# Altium schematic sheet MCU.SchDoc(MCU)
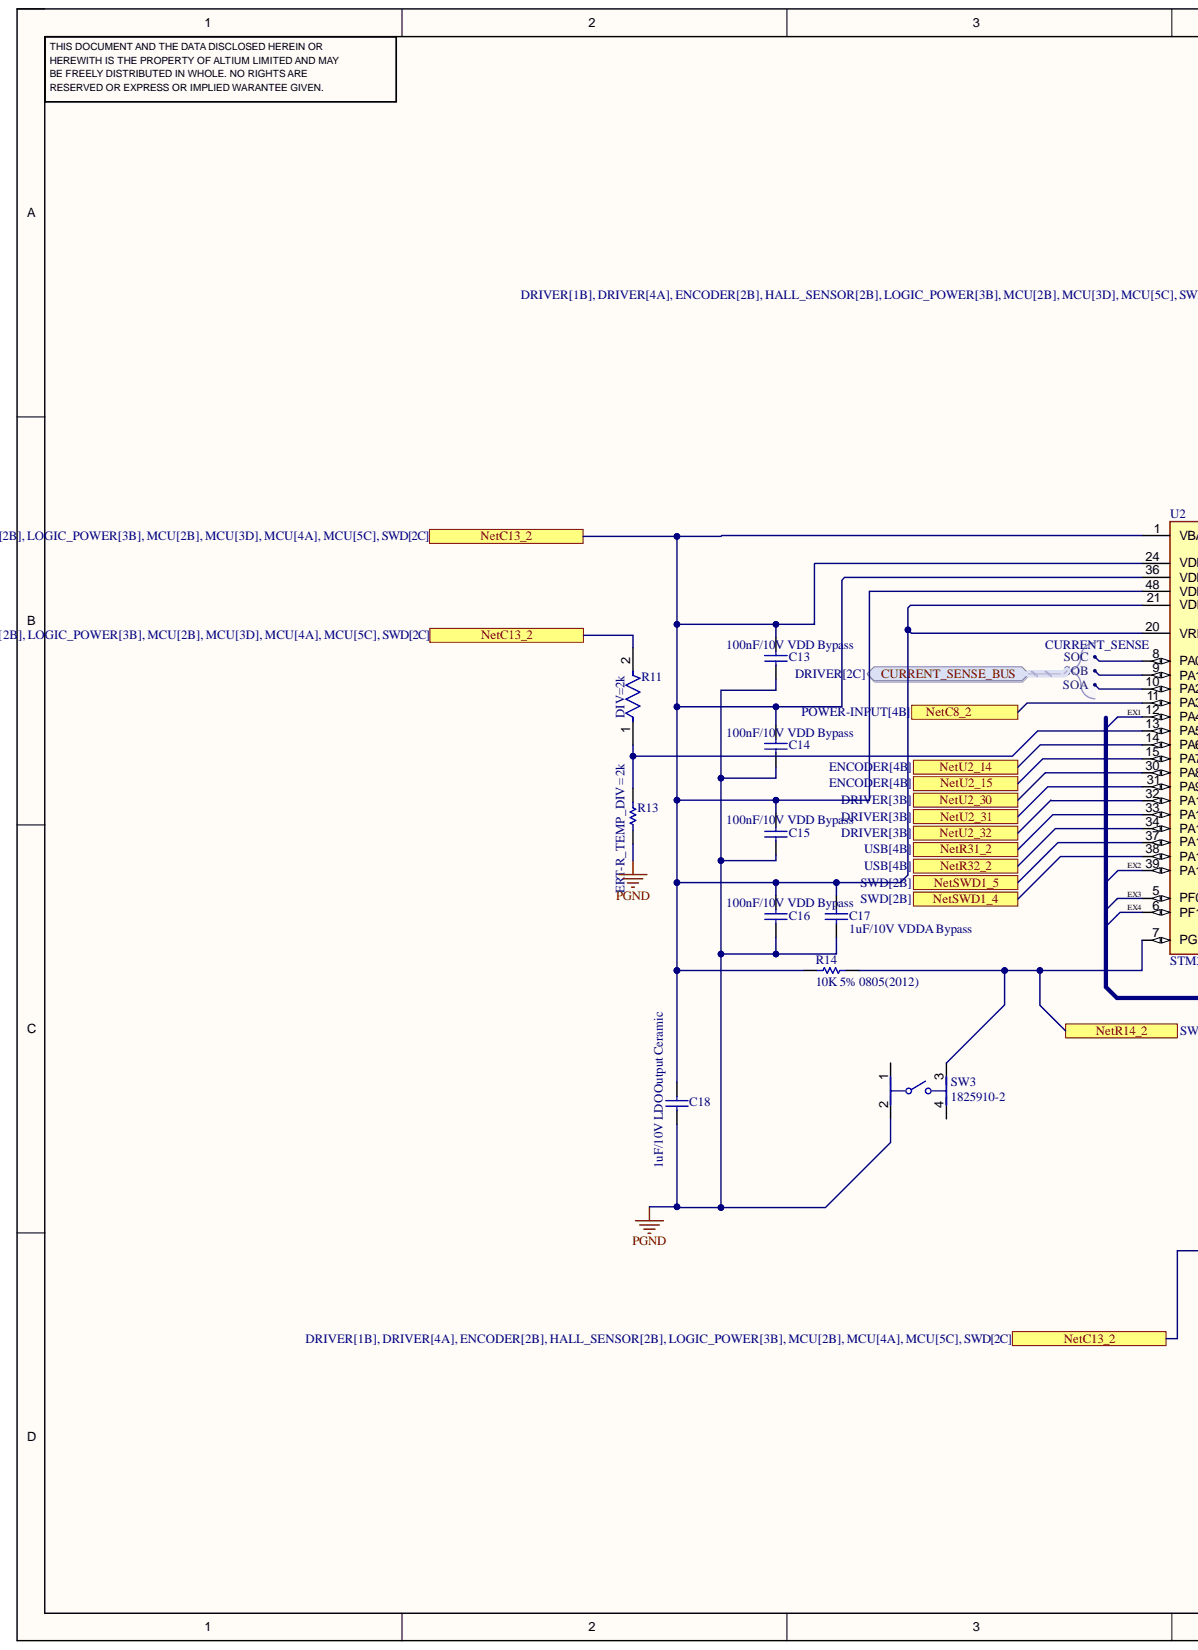
[diagram p0: MCU.SchDoc, left]
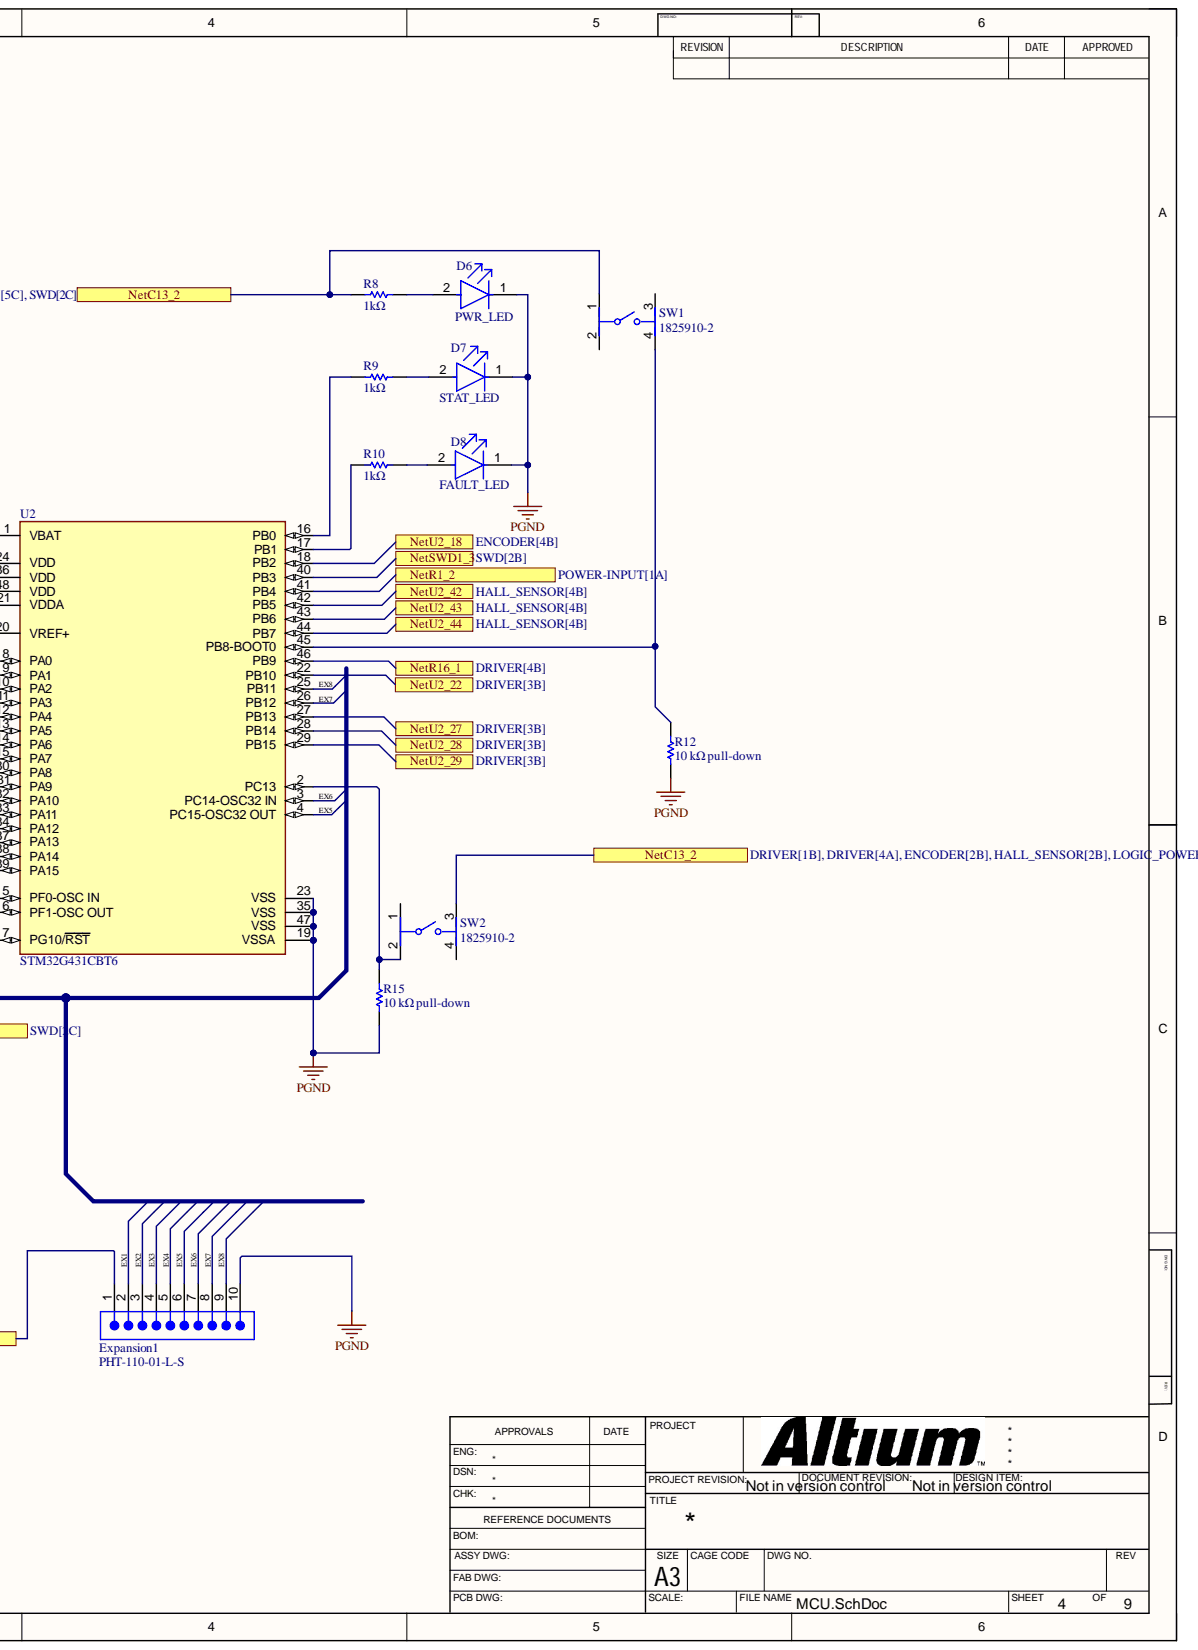
[diagram p1: MCU.SchDoc, right]

## Parts
C13  100nF/10V VDD Bypass  [CAPC0805(2012)100_N]
C14  100nF/10V VDD Bypass  [CAPC0805(2012)100_N]
C15  100nF/10V VDD Bypass  [CAPC0805(2012)100_N]
C16  100nF/10V VDD Bypass  [CAPC0805(2012)100_N]
C17  1uF/10V VDDA Bypass  [CAPC1206(3216)150_M]
C18  1uF/10V LDO Output Ceramic  [CAPC1206(3216)150_M]
D6  PWR_LED  [FP-LTST-C193KRKT-5A-MFG]
D7  STAT_LED  [FP-LTST-C193KRKT-5A-MFG]
D8  FAULT_LED  [FP-LTST-C193KRKT-5A-MFG]
Expansion1  PHT-110-01-L-S  [SMTC-PHT-110-01-X-S]
R8  1kΩ  [RESC2013X50X30ML20T20]
R9  1kΩ  [RESC2013X50X30ML20T20]
R10  1kΩ  [RESC2013X50X30ML20T20]
R11  DIV=2k  [FP-CRCW2512-e3-IPC_A]
R12  10 kΩ pull-down  [RESC0805(2012)_L]
R13  ERT-R_TEMP_DIV = 2k  [RESC1005X40X25LL05T05]
R14  10K 5% 0805(2012)  [RESC0805(2012)_L]
R15  10 kΩ pull-down  [RESC0805(2012)_L]
SW1  1825910-2  [FP-1825910-2-0]
SW2  1825910-2  [FP-1825910-2-0]
SW3  1825910-2  [FP-1825910-2-0]
U2  STM32G431CBT6  [FP-LQFP48-5B-MFG]

## Ports
3.3V-LOGIC-SUPPLY, BUS_VSENSE, CURRENT_SENSE_BUS, CURRENT_SENSE_BUS.SOA, CURRENT_SENSE_BUS.SOB, CURRENT_SENSE_BUS.SOC, ENABLE, ENC-A, ENC-B, ENC-C, FAULT, HALL-A, HALL-B, HALL-C, INHA, INHB, INHC, INLA, INLB, INLC, NRST, Q2_GATE_OFF_CTRL, SWCLK, SWDIO, SWO, USB_D+, USB_D-

## Nets
GND: C13.1 C14.1 C15.1 C16.1 C17.1 C18.1 D6.1 D7.1 D8.1 Expansion1.10 R12.1 R13.1 R15.1 SW3.2 U2.19(VSSA) U2.23(VSS) U2.35(VSS) U2.47(VSS)
BUS_VSENSE: U2.11(PA3) ; port BUS_VSENSE
EX1: Expansion1.2 U2.12(PA4)
EX2: Expansion1.3 U2.39(PA15)
EX3: Expansion1.4 U2.5(PF0-OSC_IN)
EX4: Expansion1.5 U2.6(PF1-OSC_OUT)
EX5: Expansion1.6 U2.4(PC15-OSC32_OUT)
EX6: Expansion1.7 U2.3(PC14-OSC32_IN)
EX7: Expansion1.8 U2.26(PB12)
EX8: Expansion1.9 U2.25(PB11)
FAULT: U2.46(PB9) ; port FAULT
Q2_GATE_OFF_CTRL: U2.41(PB4) ; port Q2_GATE_OFF_CTRL
SWCLK: U2.38(PA14) ; port SWCLK
SWDIO: U2.37(PA13) ; port SWDIO
SWO: U2.40(PB3) ; port SWO
NetC13_2: C13.2 C14.2 C15.2 C16.2 C17.2 C18.2 Expansion1.1 R8.1 R11.2 R14.1 SW1.1 SW2.3 U2.1(VBAT) U2.20(VREF+) U2.21(VDDA) U2.24(VDD) U2.36(VDD) U2.48(VDD) ; port 3.3V-LOGIC-SUPPLY
NetD6_2: D6.2 R8.2
NetD7_2: D7.2 R9.2
NetD8_2: D8.2 R10.2
NetR9_1: R9.1 U2.16(PB0)
NetR10_1: R10.1 U2.17(PB1)
NetR11_1: R11.1 R13.2 U2.13(PA5)
NetR12_2: R12.2 SW1.4 U2.45(PB8-BOOT0)
NetR14_2: R14.2 SW3.3 U2.7(PG10/RST) ; port NRST
NetR15_2: R15.2 SW2.2 U2.2(PC13)
NetU2_8: U2.8(PA0) ; port CURRENT_SENSE_BUS
NetU2_9: U2.9(PA1) ; port CURRENT_SENSE_BUS
NetU2_10: U2.10(PA2) ; port CURRENT_SENSE_BUS
NetU2_14: U2.14(PA6) ; port ENC-A
NetU2_15: U2.15(PA7) ; port ENC-B
NetU2_18: U2.18(PB2) ; port ENC-C
NetU2_22: U2.22(PB10) ; port ENABLE
NetU2_27: U2.27(PB13) ; port INLB
NetU2_28: U2.28(PB14) ; port INHC
NetU2_29: U2.29(PB15) ; port INLC
NetU2_30: U2.30(PA8) ; port INHA
NetU2_31: U2.31(PA9) ; port INLA
NetU2_32: U2.32(PA10) ; port INHB
NetU2_33: U2.33(PA11)
NetU2_34: U2.34(PA12)
NetU2_42: U2.42(PB5) ; port HALL-A
NetU2_43: U2.43(PB6) ; port HALL-B
NetU2_44: U2.44(PB7) ; port HALL-C

Not connected: SW1 2 3; SW2 1 4; SW3 1 4

## Library data of the parts
C13-C16 = CMP-1036-04396-1: CAP 100nF 10V ±20% 0805 (2012 Metric) Thickness 1mm SMD; Case-EIA: 0805; Case-Metric: 2012; Tolerance: Â±20%; Component Kind: Standard; Library Name: Altium Content Vault; Max Thickness: 1 mm; Rated Voltage: 10 V; Technology: SMT; Value: 100nF
C17 C18 = CMP-1037-04677-1: CAP 1uF 10V ±20% 1206 (3216 Metric) Thickness 1.45mm SMD; Case-EIA: 1206; Case-Metric: 3216; Tolerance: Â±20%; Component Kind: Standard; Library Name: Altium Content Vault; Max Thickness: 1.45 mm; Rated Voltage: 10 V; Technology: SMT; Value: 1uF
D6-D8 = CMP-2000-06623-2: LED RED CLEAR CHIP SMD; Dominant Wavelength: 631nm; Forward Current: 5mA; Forward Voltage: 2.3V; Lens Color: Colorless; Lens Style: Diffused; Luminous Intensity: 45mcd; Number of Elements: 1; Power Dissipation: 50mW; Reverse Voltage: 5V; Viewing Angle: 130°; Case Code (Imperial): 0603; Case Code (Metric): 1608; Case/Package: 0603; Color: Red; Component Kind: Standard; Depth: 0.8mm; Height: 0.35mm; Illumination Color: Red; Lead Free: Lead Free; Length: 1.6mm; Lens Transparency: Clear; Library Name: Altium Content Vault; Max Operating Temperature: 85°C; Min Operating Temperature: -55°C; Mount: Surface Mount; Number of LEDs: 1; Number of Pins: 2; Package Quantity: 3000; Packaging: Tape and Reel; Radiation Hardening: No; Test Current: 5mA; Width: 0.8mm
Expansion1 = CMP-1024-00195-1: Press Fit Header, Through-hole, Vertical, -55 to 125 degC, 2.54 mm Pitch, 10-Pin, Male, RoHS; Manufacturer: Samtec; Pitch: 2.54 mm; Component Kind: Standard; ComponentLink1Description: Manufacturer URL; ComponentLink1URL: http://www.samtec.com; ComponentLink2Description: Datasheet; ComponentLink2URL: http://www.samtec.com/documents/webfiles/pdf/pht.pdf; ComponentLink3Description: Package Specification; ComponentLink3URL: http://www.samtec.com/documents/webfiles/cpdf/PHT-1XX-XX-X-X-XXX-MKT.pdf; DatasheetVersion: F-214; Library Name: Altium Content Vault; Mounting Technology: Through-hole; Orientation: Vertical; PackageDescription: .100 Press Fit Socket Assembly; PackageReference: PHT-110-01-X-S; PackageVersion: J, 9/2009; PartNumber: PHT-110-01-L-S; RoHS: True; Temperature Range: -55 to 125 degC
R8-R10 = CMP-2001-04268-1: Manufacturer: Vishay Dale; Part Number: CRCW08051K00FKTC; Component Kind: Standard; Library Name: Altium Content Vault
R11 = CMP-02407-007764-1: RES Thick Film, 2kΩ, 1%, 1W, 100ppm/°C, 2512; Resistance: 2kR; Case/Package: 2512; Component Kind: Standard; Composition: Thick Film; Features: Automotive AEC-Q200; Height: 0.028inch; Lead Free: Lead Free; Length: 0.248inch; Library Name: Altium Content Vault; Max Operating Temperature: 155°C; Max Power Dissipation: 1W; Min Operating Temperature: -55°C; Number of Terminations: 2; Packaging: Tape and Reel; Temperature Coefficient: 100ppm/°C; Tolerance: 1%; Width: 0.124inch
R12 R14 R15 = CMP-1013-00098-1: 10K 0.125W 5% 0805 (2012 Metric)  SMD; Case-EIA: 0805; Case-Metric: 2012; Value: 10000.00 Ohm; Component Kind: Standard; Library Name: Altium Content Vault; Power: 0.125 W; Technology: SMT; Tolerance: 5 %
R13 = CMP-2002-01185-1: Manufacturer: Panasonic; Part Number: ERT-J0ET202J; Component Kind: Standard; Library Name: Altium Content Vault
SW1-SW3 = CMP-03407-000004-1: SWITCH TACTILE SPST-NO 0.05A 24V; Manufacturer: TE; Contact Current Rating: 50mA; Contact Resistance: 100mΩ; Electromechanical Life: 100000Cycles; Operating Force: 160gf; Automotive: No; Category: Switch; Component Kind: Standard; ComponentLink2Description: Datasheet; ComponentLink2URL: https://www.te.com/commerce/DocumentDelivery/DDEController?Action=showdoc&DocId=Customer+Drawing%7F1825910%7FC10%7Fpdf%7FEnglish%7FENG_CD_1825910_C10.pdf%7F1825910-2; Device Class L1: Electromechanical; Device Class L2: Switches; Device Class L3: Tactile Switches; Digikey Description: SWITCH TACTILE SPST-NO 0.05A 24V; Height: 4.6mm; Lead Free: Yes; Library Name: Altium Content Vault; Manufacturer Part Number: 1825910-2; Mount: Through Hole; Octopart: https://octopart.com/1825910-2-te+connectivity-42270502; Package: 0; RoHS: TRUE; Temperature: -35°C to +85°C; Temperature Range High: +85°C; Temperature Range Low: -35°C; Throw Configuration: SPST-NO; Voltage Rating Dc: 24V
U2 = CMP-12105-000030-1: MAINSTREAM ARM CORTEX-M4+ MCU 17; Manufacturer: STMicroelectronics; Data Bus Width: 32bits; Frequency: 170MHz; Interface: I2C,SPI,UART,USART,CAN,USB,LIN,IrDA,I2S; Max Junction Temp: +105°C; Max Supply Voltage: 3.6V; Memory Size: 128KBytes; Memory Type: FLASH; Min Supply Voltage: 1.62V; Number Of A/D Converters: 1; Number Of Adc Channels: 17; Number Of I2C Channels: 3; Number Of I/Os: 38; Number Of Pwm Channels: 31; Number Of Timers/Counters: 14; Number Of Uart Channels: 4; Ram Size: 32KBytes; Standoff Height: 0.05mm; Ambient Temperature Range High: +85°C; Ambient Temperature Range Low: -40°C; Automotive: No; Category: IC; Component Kind: Standard; ComponentLink2Description: Datasheet; ComponentLink2URL: https://www.st.com/resource/en/datasheet/stm32g431cb.pdf; Core Architecture: Arm Cortex-M4; Device Class L1: Integrated Circuits (ICs); Device Class L2: Embedded Processors and Controllers; Device Class L3: Microcontrollers; Digikey Description: MAINSTREAM ARM CORTEX-M4+ MCU 17; Height: 1.6mm; Lead Free: Yes; Library Name: Altium Content Vault; Manufacturer Part Number: STM32G431CBT6; Number Of Cores: 1; Number Of Spi Channels: 3; Number Of Usb Channels: 1; Octopart: https://octopart.com/stm32g431cbt6-stmicroelectronics-98835224; Package: LQFP48-5B; RoHS: TRUE; Temperature: -40°C to +85°C; Temperature Range High: +85°C; Temperature Range Low: -40°C
